# Altium schematic sheet Device2.SchDoc(Device2)
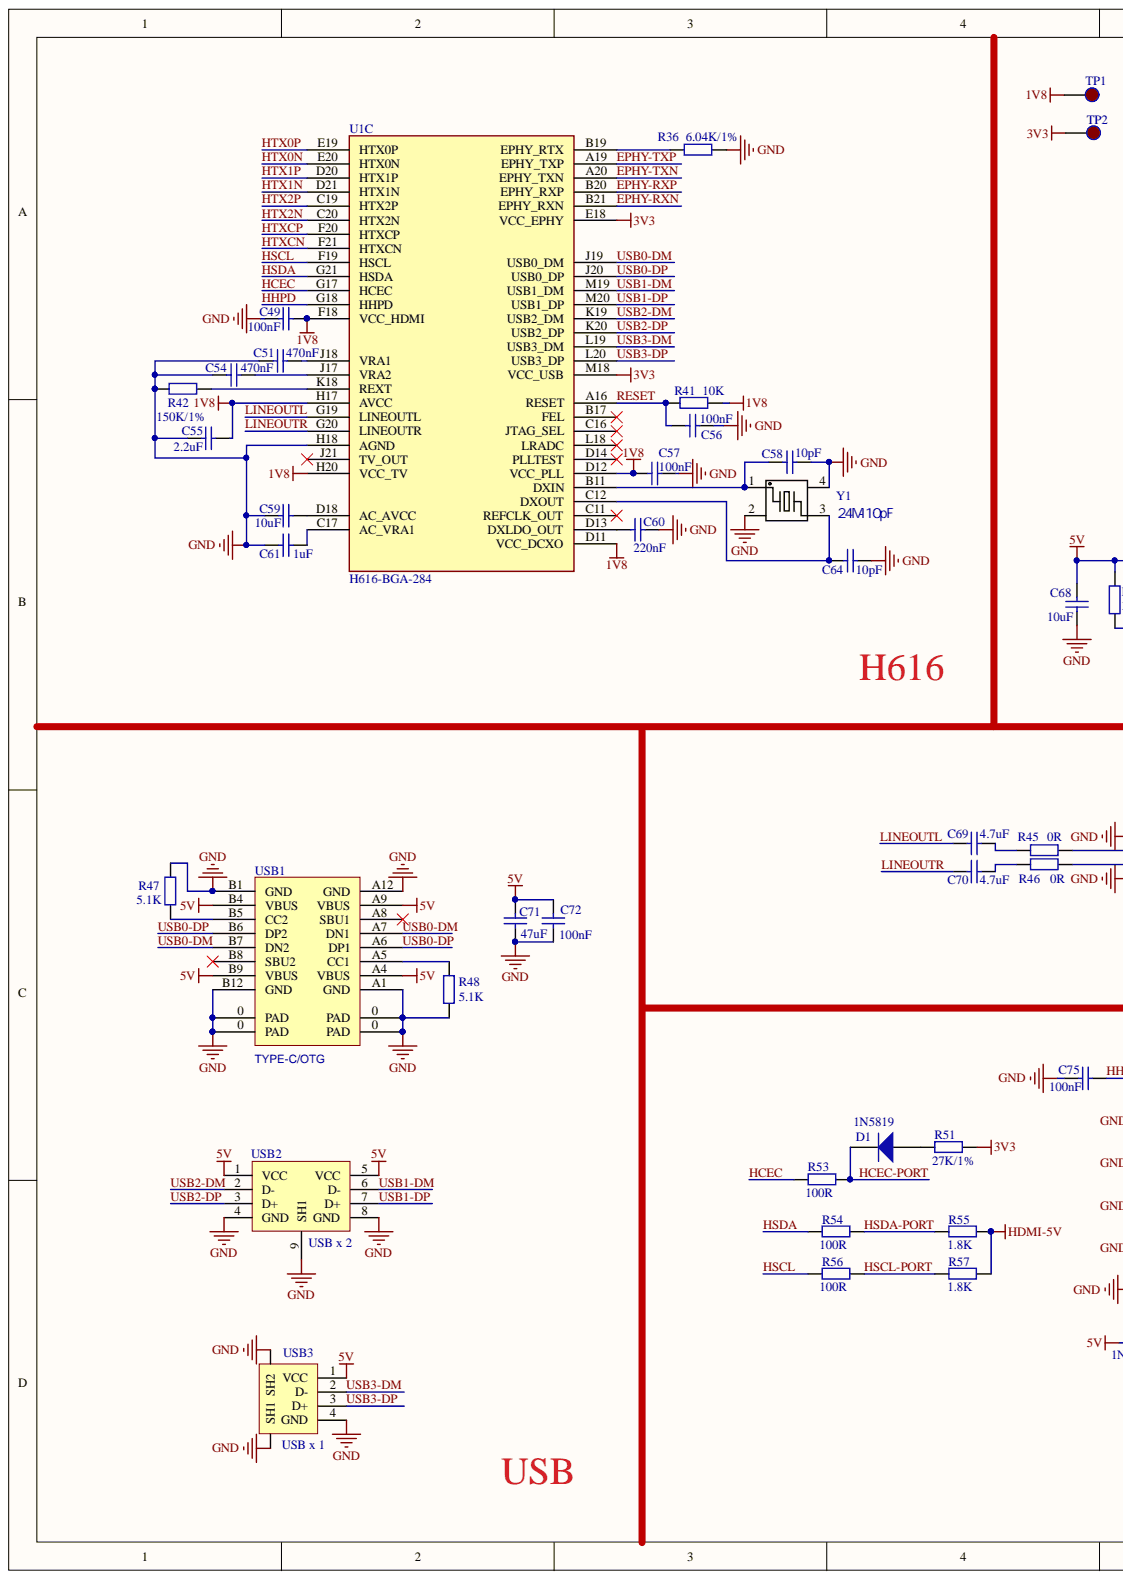
[diagram p0: Device2.SchDoc, left]
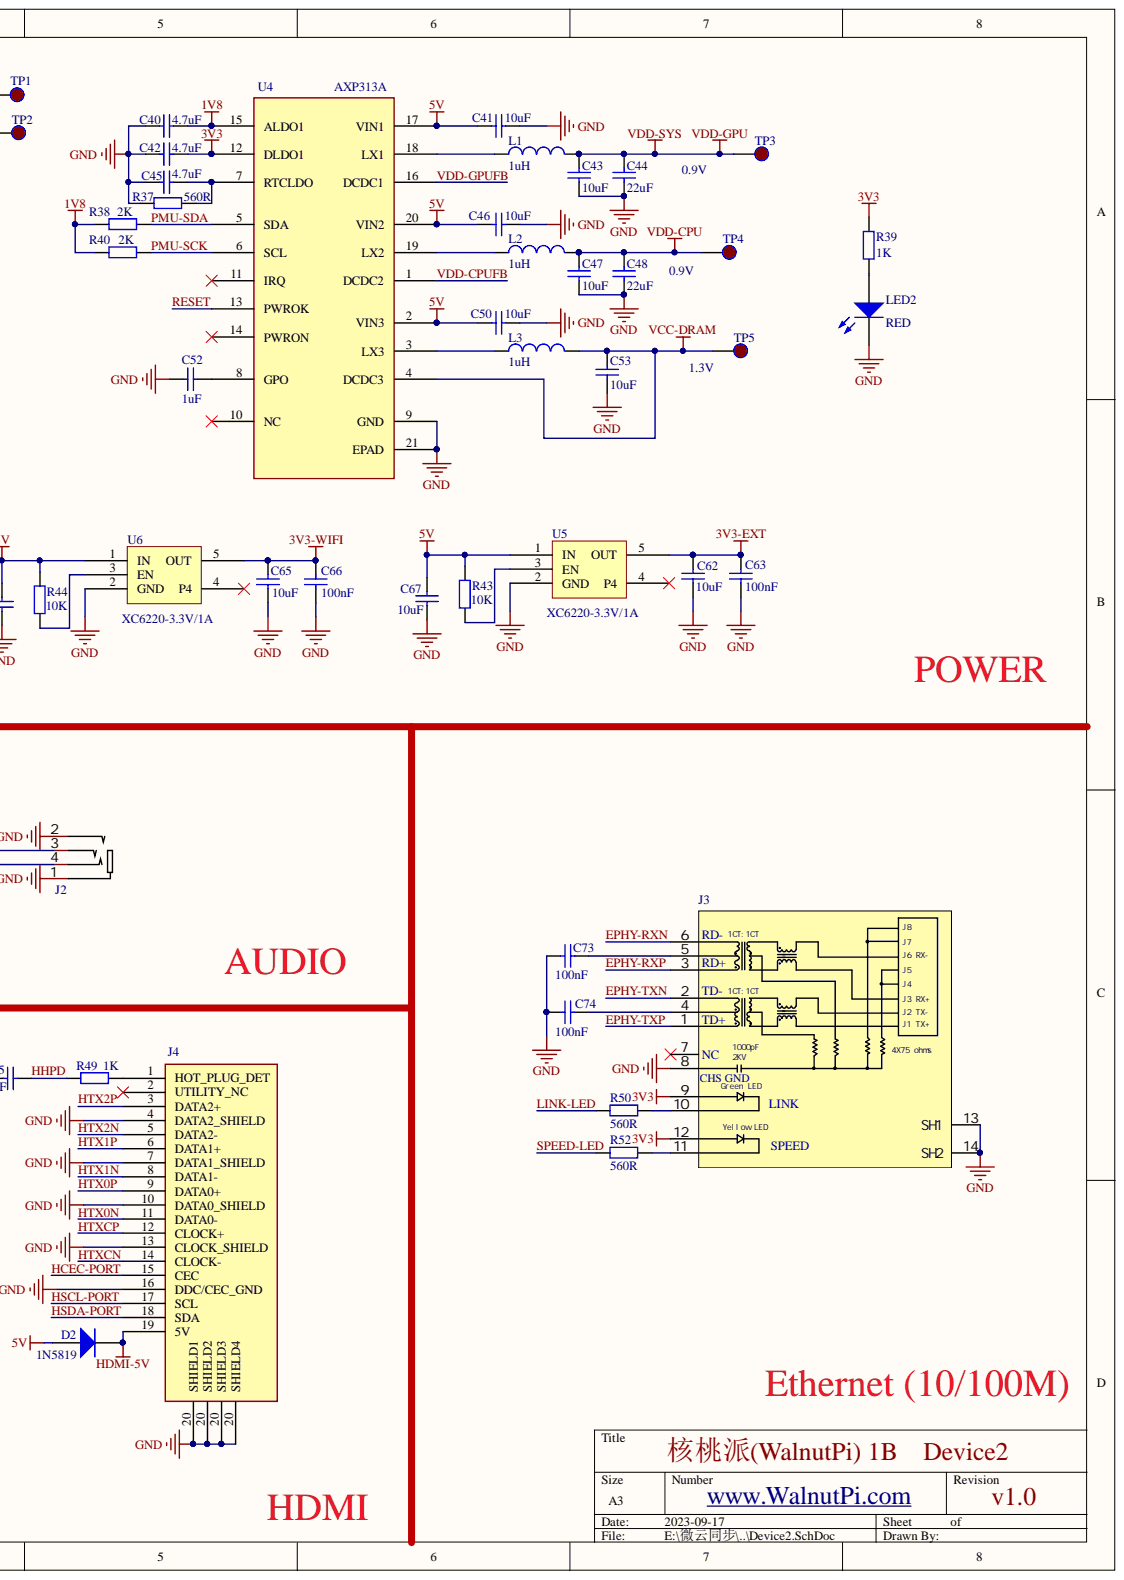
[diagram p1: Device2.SchDoc, right]

## Parts
C40  4.7uF  [R0402]
C41  10uF  [R0402]
C42  4.7uF  [R0402]
C43  10uF  [R0402]
C44  22uF  [R0603]
C45  4.7uF  [R0402]
C46  10uF  [R0402]
C47  10uF  [R0402]
C48  22uF  [R0603]
C49  100nF  [R0402]
C50  10uF  [R0402]
C51  470nF  [R0402]
C52  1uF  [R0402]
C53  10uF  [R0402]
C54  470nF  [R0402]
C55  2.2uF  [R0402]
C56  100nF  [R0402]
C57  100nF  [R0402]
C58  10pF  [R0402]
C59  10uF  [R0402]
C60  220nF  [R0402]
C61  1uF  [R0402]
C62  10uF  [R0402]
C63  100nF  [R0402]
C64  10pF  [R0402]
C65  10uF  [R0402]
C66  100nF  [R0402]
C67  10uF  [R0402]
C68  10uF  [R0402]
C69  4.7uF  [R0402]
C70  4.7uF  [R0402]
C71  47uF  [R0603]
C72  100nF  [R0402]
C73  100nF  [R0402]
C74  100nF  [R0402]
C75  100nF  [R0402]
D1  1N5819  [SOD-323]
D2  1N5819  [SOD-323]
J2  PJ-322环保  [PJ-322]  (value not drawn)
J3  HR911105A_C12074  [HR911105A]  (value not drawn)
J4  (no value)  [MicroHDMI_前插后贴]
L1  1uH  [L2520]
L2  1uH  [L2520]
L3  1uH  [L2520]
LED2  RED  [D0603]
R36  6.04K/1%  [R0402]
R37  560R  [R0402]
R38  2K  [R0402]
R39  1K  [R0402]
R40  2K  [R0402]
R41  10K  [R0402]
R42  150K/1%  [R0402]
R43  10K  [R0402]
R44  10K  [R0402]
R45  0R  [R0402]
R46  0R  [R0402]
R47  5.1K  [R0402]
R48  5.1K  [R0402]
R49  1K  [R0402]
R50  560R  [R0402]
R51  27K/1%  [R0402]
R52  560R  [R0402]
R53  100R  [R0402]
R54  100R  [R0402]
R55  1.8K  [R0402]
R56  100R  [R0402]
R57  1.8K  [R0402]
TP1  (no value)  [TESTPOINT-BUTTOM]
TP2  (no value)  [TESTPOINT-BUTTOM]
TP3  (no value)  [TESTPOINT-BUTTOM]
TP4  (no value)  [TESTPOINT-BUTTOM]
TP5  (no value)  [TESTPOINT-BUTTOM]
U1  H616-BGA-284  (part C)
U4  AXP313A  [WQFN-20_L3.0-W3.0-P0.40-BL-EP]
U5  XC6220-3.3V/1A  [SOT23-5]
U6  XC6220-3.3V/1A  [SOT23-5]
USB1  TYPE-C/OTG  [USB-TYPE-C_16PIN-MALE-TYPE-C-31-M-12]
USB2  USB x 2  [USB-A-90度-双层]
USB3  USB x 1  [USB-A-90度]
Y1  24M-10pF  [CRYSTAL-SMD_4P-2016]

## Nets
1V8: C40.2 C49.1 C55.1 C57.1 R38.1 R40.1 R41.1 TP1.1 U1.D11(VCC_DCXO) U1.D12(VCC_PLL) U1.F18(VCC_HDMI) U1.H17(AVCC) U1.H20(VCC_TV) U4.15(ALDO1)
3V3: C42.2 J3.9 J3.12 R39.1 R51.2 TP2.1 U1.E18(VCC_EPHY) U1.M18(VCC_USB) U4.12(DLDO1)
3V3-EXT: C62.2 C63.2 U5.5(OUT)
3V3-WIFI: C65.2 C66.2 U6.5(OUT)
5V: C41.2 C46.2 C50.2 C67.2 C68.2 C71.2 C72.2 D2.1 R43.1 R44.1 U4.2(VIN3) U4.17(VIN1) U4.20(VIN2) U5.1(IN) U6.1(IN) USB1.A4(VBUS) USB1.A9(VBUS) USB1.B4(VBUS) USB1.B9(VBUS) USB2.1(VCC) USB2.5(VCC) USB3.1(VCC)
GND: C40.1 C41.1 C42.1 C43.1 C44.1 C45.1 C46.1 C47.1 C48.1 C49.2 C50.1 C51.1 C52.1 C53.1 C54.1 C55.2 C56.2 C57.2 C58.2 C59.2 C60.2 C61.2 C62.1 C63.1 C64.1 C65.1 C66.1 C67.1 C68.1 C71.1 C72.1 C73.1 C74.1 C75.1 J2.1 J2.2 J3.8 J3.13(SH1) J3.14(SH2) J4.4(DATA2_SHIELD) J4.7(DATA1_SHIELD) J4.10(DATA0_SHIELD) J4.13(CLOCK_SHIELD) J4.16(DDC/CEC_GND) J4.20(SHIELD4) LED2.2 R36.2 R37.1 R42.1 R47.1 R48.1 U1.H18(AGND) U4.9(GND) U4.21(EPAD) U5.2(GND) U6.2(GND) USB1.0(PAD) USB1.A1(GND) USB1.A12(GND) USB1.B1(GND) USB1.B12(GND) USB2.4(GND) USB2.8(GND) USB2.9(SH1) USB3.4(GND) USB3.SH1 USB3.SH2 Y1.2 Y1.4
HDMI-5V: D2.2 J4.19(5V) R55.2 R57.2
VCC-DRAM: C53.2 L3.2 TP5.1 U4.4(DCDC3)
VDD-CPU: C47.2 C48.2 L2.2 TP4.1
VDD-GPU: C43.2 C44.2 L1.2 TP3.1
EPHY-RXN: J3.6 U1.B21(EPHY_RXN)
EPHY-RXP: J3.3(RD+) U1.B20(EPHY_RXP)
EPHY-TXN: J3.2 U1.A20(EPHY_TXN)
EPHY-TXP: J3.1(TD+) U1.A19(EPHY_TXP)
HCEC: R53.1 U1.G17(HCEC)
HCEC-PORT: D1.2 J4.15(CEC) R53.2
HHPD: C75.2 R49.1 U1.G18(HHPD)
HSCL: R56.1 U1.F19(HSCL)
HSCL-PORT: J4.17(SCL) R56.2 R57.1
HSDA: R54.1 U1.G21(HSDA)
HSDA-PORT: J4.18(SDA) R54.2 R55.1
HTX0N: J4.11(DATA0-) U1.E20(HTX0N)
HTX0P: J4.9(DATA0+) U1.E19(HTX0P)
HTX1N: J4.8(DATA1-) U1.D21(HTX1N)
HTX1P: J4.6(DATA1+) U1.D20(HTX1P)
HTX2N: J4.5(DATA2-) U1.C20(HTX2N)
HTX2P: J4.3(DATA2+) U1.C19(HTX2P)
HTXCN: J4.14(CLOCK-) U1.F21(HTXCN)
HTXCP: J4.12(CLOCK+) U1.F20(HTXCP)
LINEOUTL: C69.1 U1.G19(LINEOUTL)
LINEOUTR: C70.1 U1.G20(LINEOUTR)
LINK-LED: R50.1
PMU-SCK: R40.2 U4.6(SCL)
PMU-SDA: R38.2 U4.5(SDA)
RESET: C56.1 R41.2 U1.A16(RESET) U4.13(PWROK)
SPEED-LED: R52.1
USB0-DM: U1.J19(USB0_DM) USB1.A7(DN1) USB1.B7(DN2)
USB0-DP: U1.J20(USB0_DP) USB1.A6(DP1) USB1.B6(DP2)
USB1-DM: U1.M19(USB1_DM) USB2.6(D-)
USB1-DP: U1.M20(USB1_DP) USB2.7(D+)
USB2-DM: U1.K19(USB2_DM) USB2.2(D-)
USB2-DP: U1.K20(USB2_DP) USB2.3(D+)
USB3-DM: U1.L19(USB3_DM) USB3.2(D-)
USB3-DP: U1.L20(USB3_DP) USB3.3(D+)
VDD-CPUFB: U4.1(DCDC2)
VDD-GPUFB: U4.16(DCDC1)
NetC45_2: C45.2 R37.2 U4.7(RTCLDO)
NetC51_2: C51.2 U1.J18(VRA1)
NetC52_2: C52.2 U4.8(GPO)
NetC54_2: C54.2 U1.J17(VRA2)
NetC58_1: C58.1 U1.B11(DXIN) Y1.1
NetC59_1: C59.1 U1.D18(AC_AVCC)
NetC60_1: C60.1 U1.D13(DXLDO_OUT)
NetC61_1: C61.1 U1.C17(AC_VRA1)
NetC64_2: C64.2 U1.C12(DXOUT) Y1.3
NetC69_2: C69.2 R45.1
NetC70_2: C70.2 R46.1
NetC73_2: C73.2 J3.5
NetC74_2: C74.2 J3.4
NetD1_1: D1.1 R51.1
NetJ2_3: J2.3 R45.2
NetJ2_4: J2.4 R46.2
NetJ3_10: J3.10 R50.2
NetJ3_11: J3.11 R52.2
NetJ4_1: J4.1(HOT_PLUG_DET) R49.2
NetL1_1: L1.1 U4.18(LX1)
NetL2_1: L2.1 U4.19(LX2)
NetL3_1: L3.1 U4.3(LX3)
NetLED2_1: LED2.1 R39.2
NetR36_1: R36.1 U1.B19(EPHY_RTX)
NetR42_2: R42.2 U1.K18(REXT)
NetR43_2: R43.2 U5.3(EN)
NetR44_2: R44.2 U6.3(EN)
NetR47_2: R47.2 USB1.B5(CC2)
NetR48_2: R48.2 USB1.A5(CC1)

Not connected: J3 7(NC); J4 2(UTILITY_NC); U1 B17(FEL) C11(REFCLK_OUT) C16(JTAG_SEL) D14(PLLTEST) J21(TV_OUT) L18(LRADC); U4 10(NC) 11(IRQ) 14(PWRON); U5 4(P4); U6 4(P4); USB1 A8(SBU1) B8(SBU2)

## Library data of the parts
C40-C75 = Cap: Capacitor; Simulation: CAP; Signal Integrity: Cap; Published: 8-Jun-2000; PackageReference: RAD-0.3; Library Name: Miscellaneous Devices.IntLib; LatestRevisionNote: Re-released for DXP Platform.; LatestRevisionDate: 17-Jul-2002; Component Kind: Standard; Publisher: Altium Limited
D1 D2 = Diode 1N4005: 1 Amp General Purpose Rectifier; Simulation: 1N4005; Signal Integrity: 1N4005; Published: 8-Jun-2000; PackageVersion: Aug-1999; PackageReference: DO-41; PackageDescription: Diode, Axial; Body 5.2 x 2.7 mm (LxDia. max), Lead Dia. 0.86 mm (max); Library Name: Miscellaneous Devices.IntLib; LatestRevisionNote: Re-released for DXP Platform.; LatestRevisionDate: 17-Jul-2002; DatasheetVersion: 1998; ComponentLink2URL: http://www.fairchildsemi.com/ds/1N%2F1N4001.pdf; ComponentLink2Description: Datasheet; ComponentLink1URL: http://www.fairchildsemi.com/; ComponentLink1Description: Manufacturer Link; Component Kind: Standard; Code_JEDEC: DO-204-AL; Publisher: Altium Limited
J2 = PJ-322环保: Manufacturer: HOOYA(皓宇电子); Convert to PCB: yes; Connector Type: 3.5mm耳机座; Voltage Rating (Max): -; Symbol: PJ-322; Supplier Part: C2939185; Supplier Footprint: 插件; Supplier: LCSC; PCB3D: AUDIO-TH_HOOYA_PJ-322; Operating Temperature Range: -; Name: PJ-322环保; Manufacturer Part: PJ-322环保; Library Name: Schlib1.SchLib; LCSC Part Name: 3.5mm耳机座; JLCPCB Part Class: Extended Part; Device: PJ-322环保; Datasheet: https://atta.szlcsc.com/upload/public/pdf/source/20220120/FA38480529B6BC7FA51F2D845A8645A2.pdf; Current Rating (Max): -; Component Kind: Standard; Add into BOM: yes
J3 = 以太网口HR911105A: Manufacturer: HANRUN(汉仁); USOC Codes: RJ45; Number of Ports: 1; LED: 带LED; Convert to PCB: yes; Connector Type: RJ插座; Symbol: HR911105A_C12074; Supplier Part: C12074; Supplier Footprint: 插件; Supplier: LCSC; Shielding: -; Ratings: -; PCB3D: RJ45-TH_HR911105A; Name: HR911105A; Manufacturer Part: HR911105A; Library Name: Schlib1.SchLib; LCSC Part Name: RJ45 带LED 带LED灯; JLCPCB Part Class: Extended Part; Device: HR911105A_C12074; Datasheet: https://atta.szlcsc.com/upload/public/pdf/source/20170918/C12074_1505726819496976146.pdf; Component Kind: Standard; Add into BOM: yes
J4 = HDMI19_PLUG: Library Name: Schlib1.SchLib; Component Kind: Standard
L1-L3 = Inductor: Code_IEC: 1005; Simulation: INDUCTOR; Signal Integrity: Inductor; Published: 8-Jun-2000; PackageReference: 0402-A; PackageDescription: Chip Inductor; Library Name: Miscellaneous Devices.IntLib; LatestRevisionNote: IPC-7351 Footprint Added.; LatestRevisionDate: 29-May-2009; Description: Inductor; Component Kind: Standard; Code_JEITA: 0402; Publisher: Altium Limited
LED2 = LED0: Typical INFRARED GaAs LED; Simulation: LED0; Published: 8-Jun-2000; PackageReference: LED-0; Library Name: Miscellaneous Devices.IntLib; LatestRevisionNote: Mech Layer 1 removed.; LatestRevisionDate: 08-Jul-2005; Component Kind: Standard; Publisher: Altium Limited
R36-R57 = Res2: Resistor; Simulation: RESISTOR; Signal Integrity: Res; Published: 8-Jun-2000; PackageReference: AXIAL-0.4; Library Name: Miscellaneous Devices.IntLib; LatestRevisionNote: Re-released for DXP Platform.; LatestRevisionDate: 17-Jul-2002; Component Kind: Standard; Publisher: Altium Limited
TP1-TP5 = TESTPOINT: Library Name: Schlib1.SchLib; Component Kind: Standard
U1 = H616-BGA-284: SWAP_INFO: (S1+S2+S3+S4); SPLIT_INST: TRUE; Library Name: Schlib1.SchLib; Component Kind: Standard
U5 U6 = AP2112: Library Name: 01Studio.SchLib; Component Kind: Standard
USB1 = USB3-TypeC-16p-2: Component Kind: Standard
USB2 = USB-A-90度-双层: Library Name: Schlib1.SchLib; Component Kind: Standard
USB3 = USB-A-90度: Library Name: Schlib1.SchLib; Component Kind: Standard
Y1 = YXC-24M: Manufacturer: YXC(扬兴晶振); Value: 24MHz; Symbol: XL7EL89CMI-111YLC-24M; Load Capacitance: 10pF; Frequency Tolerance: ±10ppm; Frequency Stability: ±20ppm; Crystal Type: 贴片无源晶振; Convert to PCB: yes; Supplier Part: C2965581; Supplier Footprint: SMD2016-4P; Supplier: LCSC; PCB3D: OSC-SMD_4P-L2.0-W1.6-H0.8; Operating Temperature: -40℃~+85℃; Name: 24MHz; Manufacturer Part: XL7EL89CMI-111YLC-24M; Library Name: Schlib1.SchLib; LCSC Part Name: YSX211SL 24MHz ±10ppm 10pF; JLCPCB Part Class: Extended Part; Equivalent Series Resistance (ESR): -; Device: XL7EL89CMI-111YLC-24M; Datasheet: https://atta.szlcsc.com/upload/public/pdf/source/20220121/6B4B3E7EF7974858943D806BF8CACEA3.pdf; Component Kind: Standard; Add into BOM: yes
U4 = AXP313A: Library Name: Schlib1.SchLib; Component Kind: Standard
